# Altium schematic sheet 04_Temperature.SchDoc
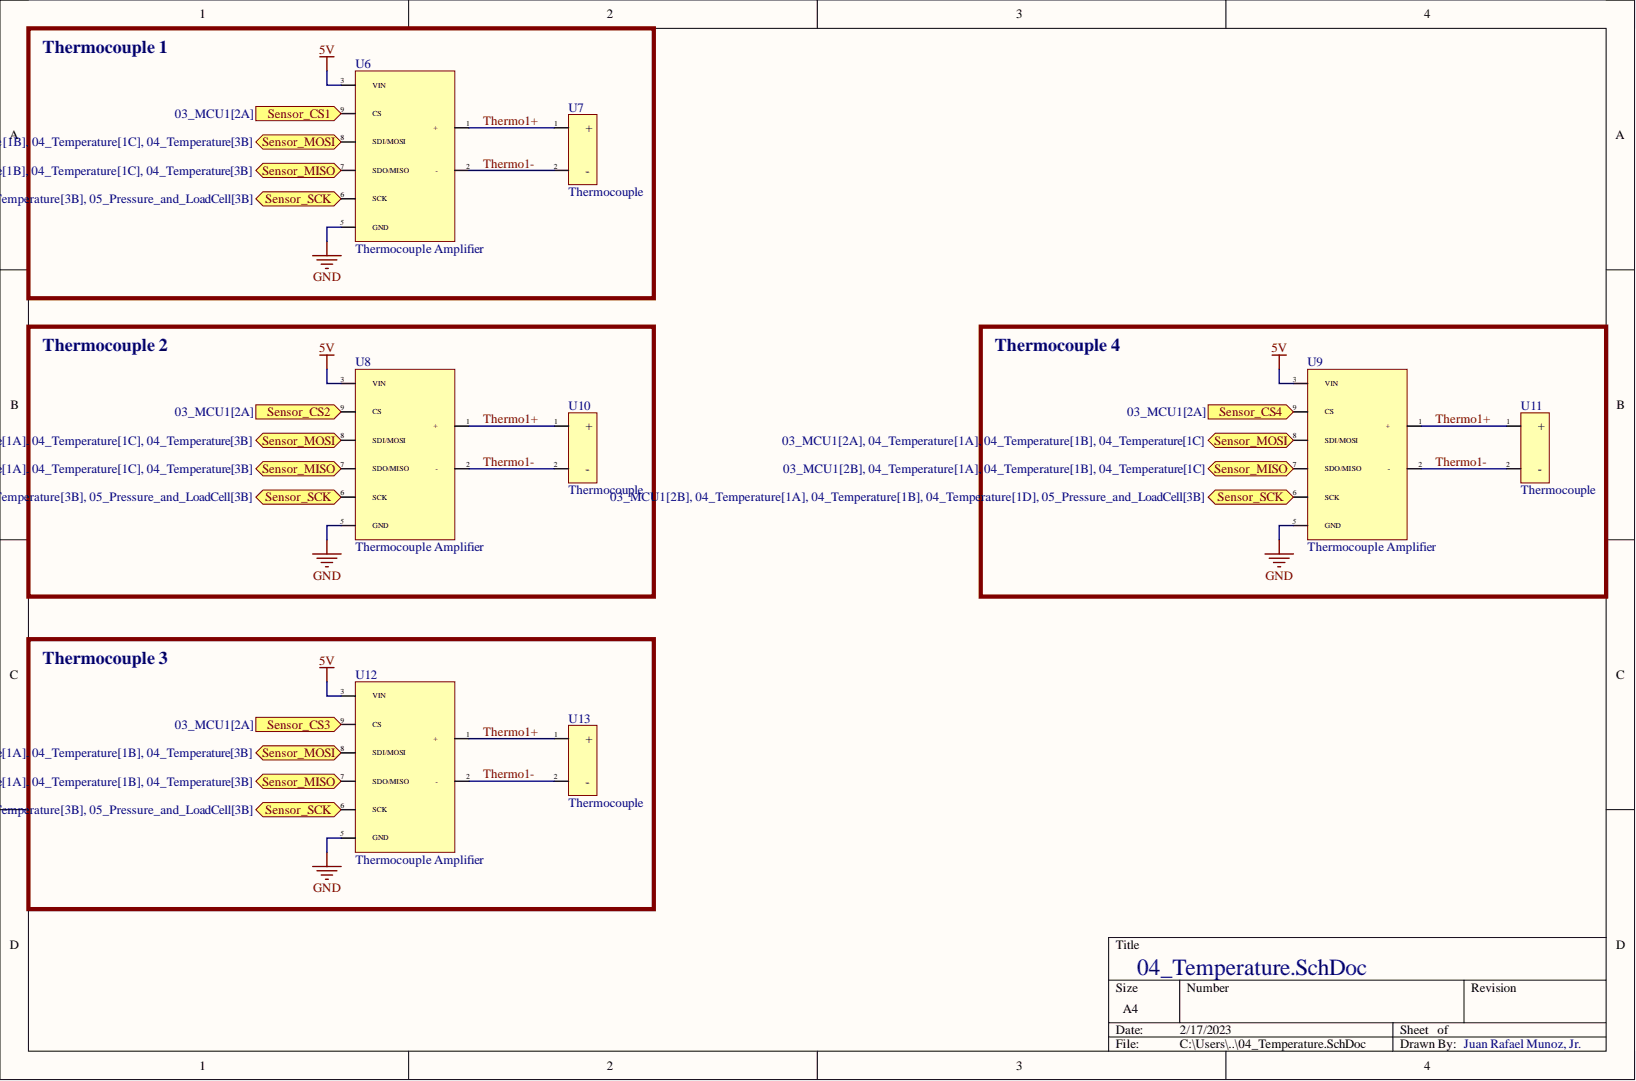
[diagram p0: 04_Temperature.SchDoc]

## Parts
U6  Thermocouple Amplifier  [Thermocouple Amplifier]
U7  Thermocouple  [Thermocouple]
U8  Thermocouple Amplifier  [Thermocouple Amplifier]
U9  Thermocouple Amplifier  [Thermocouple Amplifier]
U10  Thermocouple  [Thermocouple]
U11  Thermocouple  [Thermocouple]
U12  Thermocouple Amplifier  [Thermocouple Amplifier]
U13  Thermocouple  [Thermocouple]

## Ports
Sensor_CS1, Sensor_CS2, Sensor_CS3, Sensor_CS4, Sensor_MISO, Sensor_MOSI, Sensor_SCK

## Nets
5V: U6.3 U8.3 U9.3 U12.3
GND: U6.5 U8.5 U9.5 U12.5
Sensor_CS1: U6.9 ; port Sensor_CS1
Sensor_CS2: U8.9 ; port Sensor_CS2
Sensor_CS3: U12.9 ; port Sensor_CS3
Sensor_CS4: U9.9 ; port Sensor_CS4
Sensor_MISO: U6.7 U8.7 U9.7 U12.7 ; port Sensor_MISO
Sensor_MOSI: U6.8 U8.8 U9.8 U12.8 ; port Sensor_MOSI
Sensor_SCK: U6.6 U8.6 U9.6 U12.6 ; port Sensor_SCK
Thermo1+: U6.1(+) U7.1 U8.1(+) U9.1 U10.1 U11.1 U12.1(+) U13.1
Thermo1-: U6.2(-) U7.2 U8.2(-) U9.2 U10.2 U11.2 U12.2(-) U13.2

## Library data of the parts
U6 U8 U9 U12 = Thermocouple Amplifier: Component Kind: Standard; Library Name: Ground Support_Schlib.SchLib
U7 U10 U11 U13 = Thermocouple: Component Kind: Standard; Library Name: Ground Support_Schlib.SchLib
